# Altium schematic sheet HDMI Interface.SchDoc
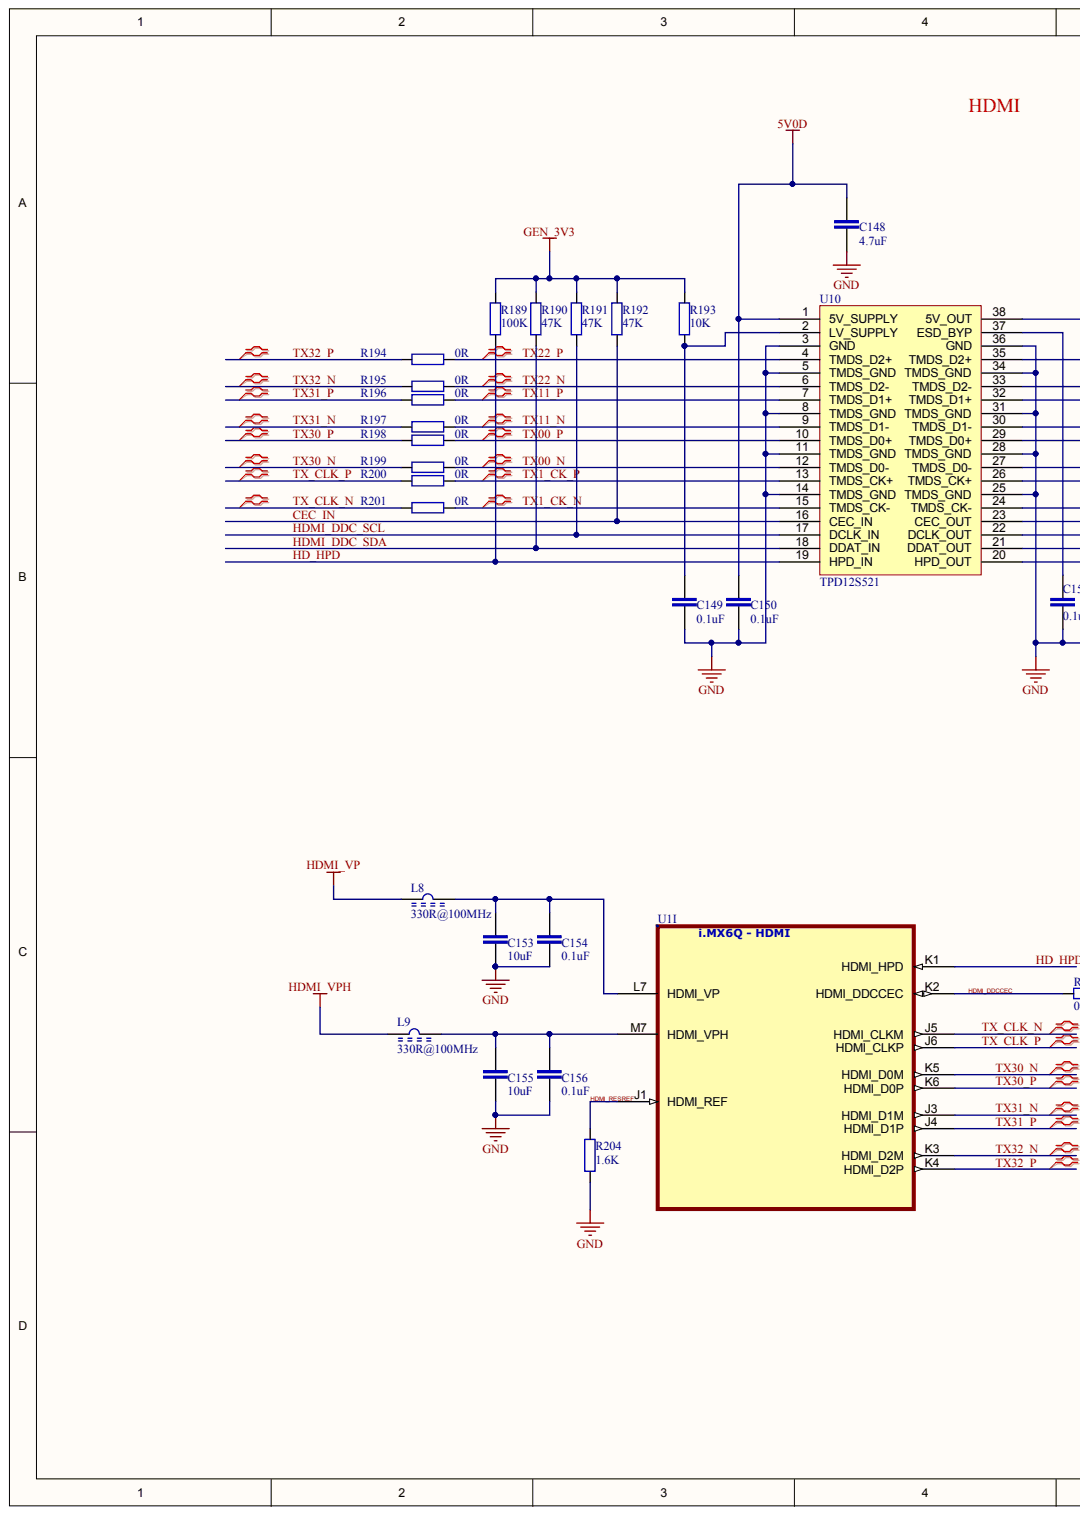
[diagram p0: HDMI Interface.SchDoc, left]
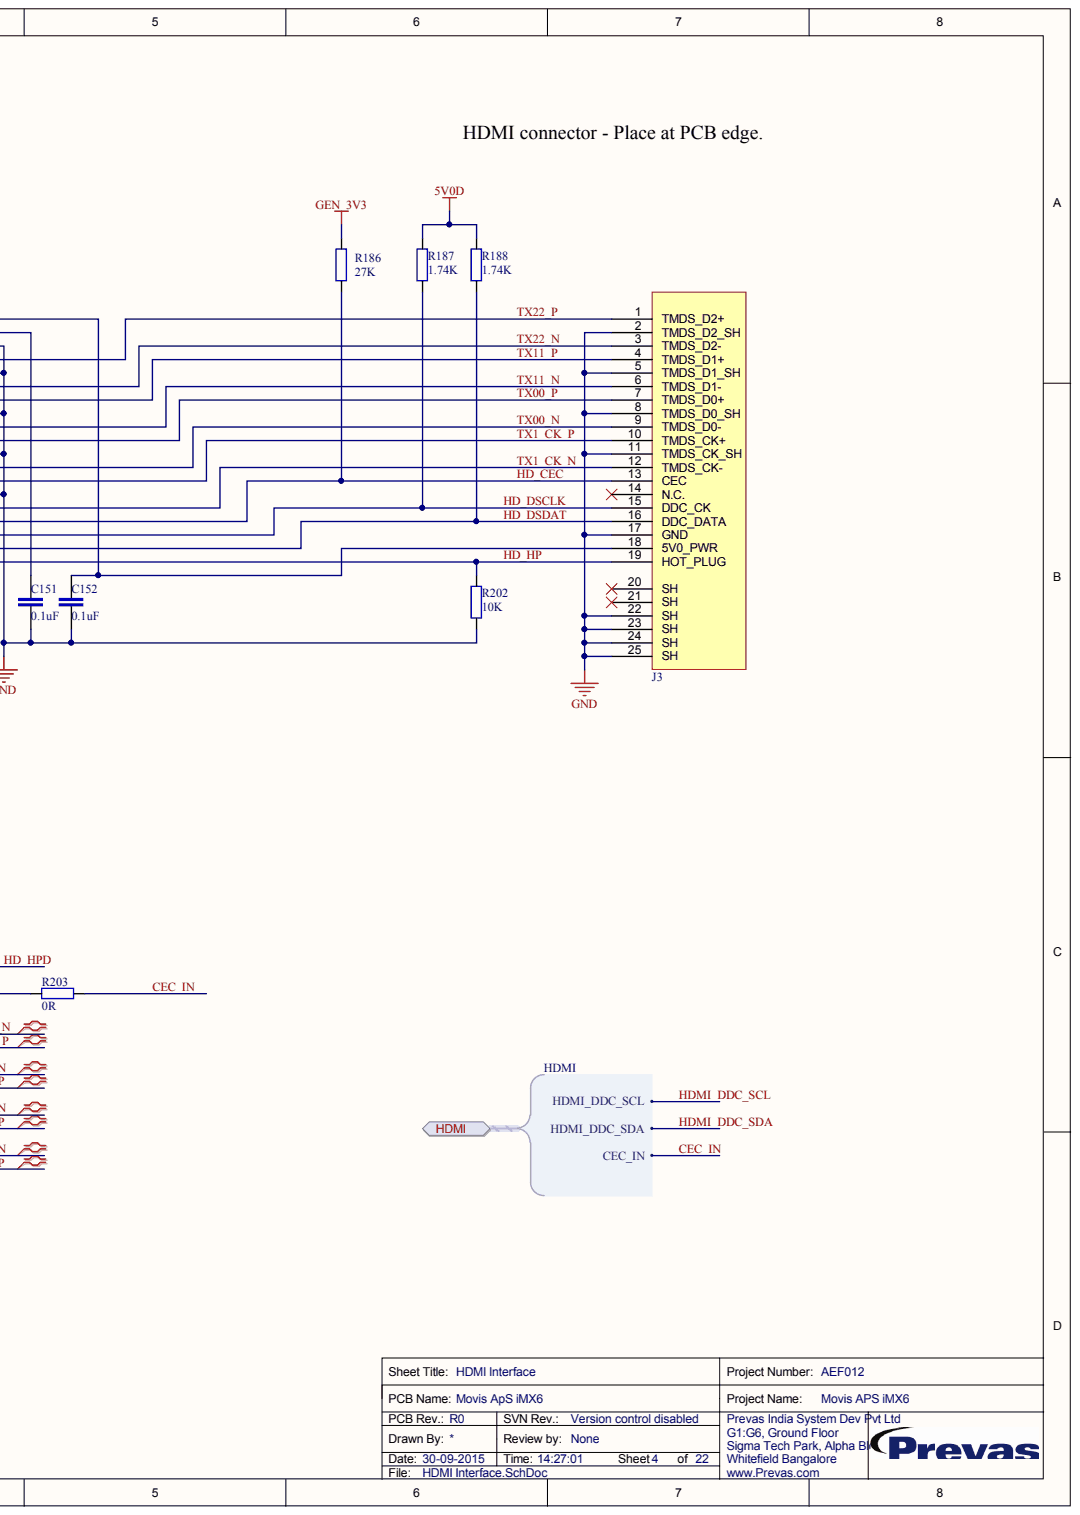
[diagram p1: HDMI Interface.SchDoc, right]

## Parts
C148  4.7uF  [CAPC1608X90N]
C149  0.1uF  [CAPC1005X55N]
C150  0.1uF  [CAPC1005X55N]
C151  0.1uF  [CAPC1005X55N]
C152  0.1uF  [CAPC1005X55N]
C153  10uF  [CAPC1608X95N]
C154  0.1uF  [CAPC1005X55N]
C155  10uF  [CAPC1608X95N]
C156  0.1uF  [CAPC1005X55N]
J3  2007435-1  [CON_HDMI_2007435-1]  (value not drawn)
L8  330R@100MHz  [INDC1608X95N]
L9  330R@100MHz  [INDC1608X95N]
R186  27K  [RESC1608X55N]
R187  1.74K  [RESC1608X55N]
R188  1.74K  [RESC1608X55N]
R189  100K  [RESC1608X55N]
R190  47K  [RESC1608X55N]
R191  47K  [RESC1608X55N]
R192  47K  [RESC1608X55N]
R193  10K  [RESC1608X55N]
R194  0R  [RESC1608X55N]
R195  0R  [RESC1608X55N]
R196  0R  [RESC1608X55N]
R197  0R  [RESC1608X55N]
R198  0R  [RESC1608X55N]
R199  0R  [RESC1608X55N]
R200  0R  [RESC1608X55N]
R201  0R  [RESC1608X55N]
R202  10K  [RESC1608X55N]
R203  0R  [RESC1608X55N]
R204  1.6K  [RESC1608X55N]
U1  MCIMX6Q6AVT10AC  [BGA624C80P25X25_2100X2100X160]  (value not drawn)  (part I)
U10  TPD12S521  [SOP50P640X120-38N]

## Ports
HDMI, HDMI.CEC_IN, HDMI.HDMI_DDC_SCL, HDMI.HDMI_DDC_SDA

## Nets
5V0D: C148.2 C150.2 R187.2 R188.2 U10.1(5V_SUPPLY)
GEN_3V3: R186.2 R189.2 R190.2 R191.2 R192.2 R193.2
GND: C148.1 C149.1 C150.1 C151.1 C152.1 C153.1 C154.1 C155.1 C156.1 J3.2(TMDS_D2_SH) J3.5(TMDS_D1_SH) J3.8(TMDS_D0_SH) J3.11(TMDS_CK_SH) J3.17(GND) J3.22(SH) J3.23(SH) J3.24(SH) J3.25(SH) R202.1 R204.1 U10.3(GND) U10.5(TMDS_GND) U10.8(TMDS_GND) U10.11(TMDS_GND) U10.14(TMDS_GND) U10.25(TMDS_GND) U10.28(TMDS_GND) U10.31(TMDS_GND) U10.34(TMDS_GND) U10.36(GND)
HDMI_VP: L8.1
HDMI_VPH: L9.1
CEC_IN: R192.1 R203.1 U10.16(CEC_IN) ; port HDMI
HD_CEC: J3.13(CEC) R186.1 U10.23(CEC_OUT)
HD_DSCLK: J3.15(DDC_CK) R187.1 U10.22(DCLK_OUT)
HD_DSDAT: J3.16(DDC_DATA) R188.1 U10.21(DDAT_OUT)
HD_HP: J3.19(HOT_PLUG) R202.2 U10.20(HPD_OUT)
HD_HPD: R189.1 U1.K1(HDMI_HPD) U10.19(HPD_IN)
HDMI_DDC_SCL: R191.1 U10.17(DCLK_IN) ; port HDMI
HDMI_DDC_SDA: R190.1 U10.18(DDAT_IN) ; port HDMI
HDMI_DDCCEC: R203.2 U1.K2(HDMI_DDCCEC)
HDMI_RESREF: R204.2 U1.J1(HDMI_REF)
TX00_N: J3.9(TMDS_D0-) R199.2 U10.12(TMDS_D0-) U10.27(TMDS_D0-)
TX00_P: J3.7(TMDS_D0+) R198.2 U10.10(TMDS_D0+) U10.29(TMDS_D0+)
TX1_CK_N: J3.12(TMDS_CK-) R201.2 U10.15(TMDS_CK-) U10.24(TMDS_CK-)
TX1_CK_P: J3.10(TMDS_CK+) R200.2 U10.13(TMDS_CK+) U10.26(TMDS_CK+)
TX11_N: J3.6(TMDS_D1-) R197.2 U10.9(TMDS_D1-) U10.30(TMDS_D1-)
TX11_P: J3.4(TMDS_D1+) R196.2 U10.7(TMDS_D1+) U10.32(TMDS_D1+)
TX22_N: J3.3(TMDS_D2-) R195.2 U10.6(TMDS_D2-) U10.33(TMDS_D2-)
TX22_P: J3.1(TMDS_D2+) R194.2 U10.4(TMDS_D2+) U10.35(TMDS_D2+)
TX30_N: R199.1 U1.K5(HDMI_D0M)
TX30_P: R198.1 U1.K6(HDMI_D0P)
TX31_N: R197.1 U1.J3(HDMI_D1M)
TX31_P: R196.1 U1.J4(HDMI_D1P)
TX32_N: R195.1 U1.K3(HDMI_D2M)
TX32_P: R194.1 U1.K4(HDMI_D2P)
TX_CLK_N: R201.1 U1.J5(HDMI_CLKM)
TX_CLK_P: R200.1 U1.J6(HDMI_CLKP)
NetC149_2: C149.2 R193.1 U10.2(LV_SUPPLY)
NetC151_2: C151.2 U10.37(ESD_BYP)
NetC152_2: C152.2 J3.18(5V0_PWR) U10.38(5V_OUT)
NetC153_2: C153.2 C154.2 L8.2 U1.L7(HDMI_VP)
NetC155_2: C155.2 C156.2 L9.2 U1.M7(HDMI_VPH)

Not connected: J3 14(N.C.) 20(SH) 21(SH)

## Library data of the parts
C148 = INNISI-102: Ceramic capacitor X5R 0603; ref_part_type: /capacitor/non_polarized/ceramic/; source_manufacturer_1: TAIYO YUDEN; source_manufacturer_1_pn: EMK107ABJ475KA-T; cae_altium_pcb_status: Prototype; Database Table Name: altium_default; db_rev: 7663; elec_temp_range: -55 to +85; elec_tolerance: 10%; elec_voltage_rating: 16V; phy_height: 0.90mm; phy_mounting: SMD; phy_packaging_type: 0603; ref_keyword: Multilayer chip capacitor; cae_altium_sch_status: Prototype; Component Kind: Standard; ComponentLink1Description: Datasheet; db_created: 2015-08-04 09:58:51.0; db_last_updated: 04-08-2015 09:58:53; db_local_id: INNISI-102; db_status: Prototype; elec_value: 4.7uF; phy_material: X5R; Library Name: altium_mysql.dblib
C149-C152 C154 C156 = INNISI-73: CAP CER 0.1UF 50V 10% X5R 0402; ref_part_type: /capacitor/non_polarized/ceramic/; source_manufacturer_1: TDK Corporation; source_manufacturer_1_pn: C1005X5R1H104K050BB; cae_altium_pcb_status: Prototype; Database Table Name: altium_default; db_rev: 7569; elec_temp_range: -55 to +85 C; phy_height: 0.55mm; phy_mounting: SMD; phy_packaging_type: 0402; ref_datasheet: INNISI-71; cae_altium_sch_status: Prototype; Component Kind: Standard; ComponentLink1Description: Datasheet; ComponentLink1URL: https://cop201.prevas.dk/viewvc/datasheet/INNISI-71.pdf?root=hw_components&view=co; db_created: 2015-08-03 03:34:59.0; db_last_updated: 03-08-2015 03:35:01; db_local_id: INNISI-73; db_status: Prototype; elec_tolerance: ±10%; elec_value: 0.1uF; elec_voltage_rating: 50V; phy_material: X5R; ref_keyword: CAP CER 0.1UF 50V 10% X5R 0402; Library Name: altium_mysql.dblib
C153 C155 = innisi-78: CAP CER 10UF 16V 10% X5R 0603; ref_part_type: /capacitor/non_polarized/ceramic/; source_manufacturer_1: Murata; source_manufacturer_1_pn: GRM188R61C106KAALD; cae_altium_pcb_status: Prototype; Database Table Name: altium_default; db_rev: 7574; elec_temp_range: -55 to +85C; phy_height: 0.95mm; phy_mounting: SMD; phy_packaging_type: 0603; ref_datasheet: INNISI-75; ref_keyword: Ceramic capacitor X5R 0603; cae_altium_sch_status: Prototype; Component Kind: Standard; ComponentLink1Description: Datasheet; ComponentLink1URL: https://cop201.prevas.dk/viewvc/datasheet/INNISI-75.pdf?root=hw_components&view=co; db_created: 2015-08-03 05:08:42.0; db_last_updated: 03-08-2015 05:08:44; db_local_id: INNISI-78; db_status: Prototype; elec_tolerance: 10%; elec_value: 10uF; elec_voltage_rating: 16V; phy_material: X5R; Library Name: altium_mysql.dblib
J3 = INNISI-64: HDMI, Displayport & DVI Connectors RECPT TYPE A 1P FLAG; ref_part_type: /connector/; source_manufacturer_1: TE Connectivity; cae_altium_pcb_status: Prototype; Database Table Name: altium_default; db_rev: 7514; elec_current_rating: 0.5 A; elec_voltage_rating: 40 V; phy_material: Copper Alloy; phy_mounting: Leaded; ref_datasheet: INNISI-63; ref_keyword: HDMI Connector; cae_altium_sch_status: Prototype; Component Kind: Standard; ComponentLink1Description: Datasheet; ComponentLink1URL: https://cop201.prevas.dk/viewvc/datasheet/INNISI-63.pdf?root=hw_components&view=co; db_created: 2015-06-18 08:45:40.0; db_last_updated: 10-07-2015 09:44:48; db_local_id: INNISI-64; db_status: Prototype; elec_value: 2007435-1; source_manufacturer_1_pn: 2007435-1; Library Name: altium_mysql.dblib
L8 L9 = TELA-164: Chip EMIFIL Inductor Type, 330R@100MHz, 0.15R@0Hz; ref_part_type: /inductor/lossy/; source_manufacturer_1: Murata; source_manufacturer_1_pn: BLM18PG331SN1*; cae_altium_pcb_status: Prototype; Database Table Name: altium_default; db_rev: 5543; elec_current_rating: 1.2A; elec_frequency_rating: 100MHz; elec_temp_range: -55 to 125; elec_tolerance: 25%; phy_height: 0.95mm; phy_material: Ferrite; phy_mounting: SMD; phy_packaging_type: 0603; ref_datasheet: SOMO-49; ref_keyword: Ferrite bead 0603; cae_altium_sch_status: Prototype; Component Kind: Standard; ComponentLink1Description: Datasheet; ComponentLink1URL: https://cop201.prevas.dk/viewvc/datasheet/SOMO-49.pdf?root=hw_components&view=co; db_created: 2013-03-14 15:35:31.0; db_last_updated: 14-03-2013 16:11:58; db_local_id: TELA-164; db_status: Prototype; elec_value: 330R@100MHz; Library Name: altium_mysql.dblib
R186 = INVIHA-18: RES SMD 27K OHM 1% 1/10W 0603; ref_part_type: /resistor/fixed/; source_manufacturer_1: Yageo; source_manufacturer_1_pn: RC0603FR-0727KL; cae_altium_pcb_status: Prototype; Database Table Name: altium_default; db_rev: 7600; elec_power_rating: 0.1W; elec_temp_range: -55 to +155° C; phy_height: 0.55mm; phy_packaging_type: 0603; ref_datasheet: INVIHA-2; ref_keyword: Chip Resistor; cae_altium_sch_status: Prototype; Component Kind: Standard; ComponentLink1Description: Datasheet; ComponentLink1URL: https://cop201.prevas.dk/viewvc/datasheet/INVIHA-2.pdf?root=hw_components&view=co; db_created: 2015-08-03 07:06:44.0; db_last_updated: 03-08-2015 7:06:46 AM; db_local_id: INVIHA-18; db_status: Prototype; elec_tolerance: 1%; elec_value: 27K; phy_mounting: SMD; Library Name: altium_mysql.dblib
R187 R188 = INVIHA-19: RES SMD 1.74K OHM 1% 1/10W 0603; ref_part_type: /resistor/fixed/; source_manufacturer_1: Yageo; source_manufacturer_1_pn: RC0603FR-071K74L; cae_altium_pcb_status: Prototype; Database Table Name: altium_default; db_rev: 7601; elec_power_rating: 0.1W; elec_temp_range: -55 to +155° C; phy_height: 0.55mm; phy_packaging_type: 0603; ref_datasheet: INVIHA-2; ref_keyword: Chip Resistor; cae_altium_sch_status: Prototype; Component Kind: Standard; ComponentLink1Description: Datasheet; ComponentLink1URL: https://cop201.prevas.dk/viewvc/datasheet/INVIHA-2.pdf?root=hw_components&view=co; db_created: 2015-08-03 07:11:02.0; db_last_updated: 03-08-2015 7:11:04 AM; db_local_id: INVIHA-19; db_status: Prototype; elec_tolerance: 1%; elec_value: 1.74K; phy_mounting: SMD; Library Name: altium_mysql.dblib
R189 = INVIHA-20: RES SMD 100K OHM 1% 1/10W 0603; ref_part_type: /resistor/fixed/; source_manufacturer_1: Yageo; source_manufacturer_1_pn: RC0603FR-07100KL; cae_altium_pcb_status: Prototype; Database Table Name: altium_default; db_rev: 7602; elec_power_rating: 0.1W; elec_temp_range: -55 to +155° C; phy_height: 0.55mm; phy_packaging_type: 0603; ref_keyword: Chip Resistor; cae_altium_sch_status: Prototype; Component Kind: Standard; ComponentLink1Description: Datasheet; ComponentLink1URL: https://cop201.prevas.dk/viewvc/datasheet/INVIHA-2.pdf?root=hw_components&view=co; db_created: 2015-08-03 07:16:43.0; db_last_updated: 03-08-2015 7:16:45 AM; db_local_id: INVIHA-20; db_status: Prototype; elec_tolerance: 1%; elec_value: 100K; phy_mounting: SMD; ref_datasheet: INVIHA-2; Library Name: altium_mysql.dblib
R190-R192 = INVIHA-21: RES SMD 47K OHM 1% 1/10W 0603; ref_part_type: /resistor/fixed/; source_manufacturer_1: Yageo; source_manufacturer_1_pn: RC0603FR-0747KL; cae_altium_pcb_status: Prototype; Database Table Name: altium_default; db_rev: 7604; elec_power_rating: 0.1W; elec_temp_range: -55 to +155° C; phy_height: 0.55mm; phy_packaging_type: 0603; ref_keyword: Chip Resistor; cae_altium_sch_status: Prototype; Component Kind: Standard; ComponentLink1Description: Datasheet; ComponentLink1URL: https://cop201.prevas.dk/viewvc/datasheet/INVIHA-2.pdf?root=hw_components&view=co; db_created: 2015-08-03 07:24:13.0; db_last_updated: 03-08-2015 7:24:15 AM; db_local_id: INVIHA-21; db_status: Prototype; elec_tolerance: 1%; elec_value: 47K; phy_mounting: SMD; ref_datasheet: INVIHA-2; Library Name: altium_mysql.dblib
R193 R202 = INVIHA-3: RES SMD 10K OHM 1% 1/10W 0603; ref_part_type: /resistor/fixed/; source_manufacturer_1: Yageo; source_manufacturer_1_pn: RC0603FR-0710KL; cae_altium_pcb_status: Prototype; Database Table Name: altium_default; db_rev: 7575; elec_power_rating: 0.1W; elec_temp_range: -55 to +155° C; phy_height: 0.55mm; phy_packaging_type: 0603; ref_datasheet: INVIHA-2; ref_keyword: Chip Resistor; cae_altium_sch_status: Prototype; Component Kind: Standard; ComponentLink1Description: Datasheet; ComponentLink1URL: https://cop201.prevas.dk/viewvc/datasheet/INVIHA-2.pdf?root=hw_components&view=co; db_created: 2015-08-03 05:39:03.0; db_last_updated: 03-08-2015 5:39:05 AM; db_local_id: INVIHA-3; db_status: Prototype; elec_tolerance: 1%; elec_value: 10K; phy_mounting: SMD; Library Name: altium_mysql.dblib
R194-R201 R203 = INVIHA-4: RES SMD 0.0 OHM JUMPER 1/10W; ref_part_type: /resistor/fixed/; source_manufacturer_1: Panasonic Electronic Components; source_manufacturer_1_pn: ERJ-3GEY0R00V; cae_altium_pcb_status: Prototype; Database Table Name: altium_default; db_rev: 7580; elec_power_rating: 0.1W; elec_temp_range: -55 to +155° C; phy_height: 0.55mm; phy_packaging_type: 0603; ref_datasheet: INVIHA-3; ref_keyword: Chip Resistor; cae_altium_sch_status: Prototype; Component Kind: Standard; ComponentLink1Description: Datasheet; ComponentLink1URL: https://cop201.prevas.dk/viewvc/datasheet/INVIHA-3.pdf?root=hw_components&view=co; db_created: 2015-08-03 05:59:19.0; db_last_updated: 03-08-2015 5:59:21 AM; db_local_id: INVIHA-4; db_status: Prototype; elec_value: 0R; phy_mounting: SMD; Library Name: altium_mysql.dblib
R204 = INVIHA-22: RES SMD 1.6K OHM 1% 1/10W 0603; ref_part_type: /resistor/fixed/; source_manufacturer_1: Yageo; source_manufacturer_1_pn: RC0603FR-071K6L; cae_altium_pcb_status: Prototype; Database Table Name: altium_default; db_rev: 7605; elec_power_rating: 0.1W; elec_temp_range: -55 to +155° C; phy_height: 0.55mm; phy_packaging_type: 0603; ref_keyword: Chip Resistor; cae_altium_sch_status: Prototype; Component Kind: Standard; ComponentLink1Description: Datasheet; ComponentLink1URL: https://cop201.prevas.dk/viewvc/datasheet/INVIHA-2.pdf?root=hw_components&view=co; db_created: 2015-08-03 07:25:35.0; db_last_updated: 03-08-2015 7:25:37 AM; db_local_id: INVIHA-22; db_status: Prototype; elec_tolerance: 1%; elec_value: 1.6K; phy_mounting: SMD; ref_datasheet: INVIHA-2; Library Name: altium_mysql.dblib
U1 = INRAAN-5: i.MX 6Dual/6Quad; ref_part_type: /integrated/digital/processor/general/; AGILE_PN: 344-01218; CAD_APPROVED: Yes; cae_altium_pcb_status: Prototype; CLASS: IC; Database Table Name: altium_default; db_rev: 6247; elec_temp_range: -40 to +105; elec_voltage_rating: 1.5V; MFG_NAME01: FREESCALE SEMICONDUCTOR; phy_height: 1.85; phy_mounting: SMD; phy_packaging_type: FCPBGA96_624; ref_datasheet: INRAAN-6; cae_altium_sch_status: Prototype; Component Kind: Standard; ComponentLink1Description: Datasheet; ComponentLink1URL: https://cop201.prevas.dk/viewvc/datasheet/INRAAN-6.pdf?root=hw_components&view=co; db_created: 2013-10-21 12:25:57.0; db_last_updated: 27-12-2013 09:32:57; db_local_id: INRAAN-5; db_status: Prototype; elec_value: MCIMX6Q6AVT10AC; HEIGHT: 2.16MM; MFG_PN01: MCIMX6Q6AVT10AC; ref_keyword: i.MX 6Dual/6Quad; ROHS: Yes; source_manufacturer_1: Freescale Semiconductor; source_manufacturer_1_pn: MCIMX6Q6AVT10AC; Library Name: altium_mysql.dblib
U10 = INRAAN-239: ESD Suppressors HDMI RECEIVER PORT PROTECTION; ref_part_type: /filter/esd/; source_manufacturer_1: Texas Instruments; cae_altium_pcb_status: Prototype; Database Table Name: altium_default; db_rev: 6944; elec_temp_range: - 40 C to + 85 C; phy_height: 1.2mm; phy_mounting: SMD; phy_packaging_type: TSSOP-38; ref_datasheet: INRAAN-224; ref_keyword: ESD Protection Device; cae_altium_sch_status: Prototype; Component Kind: Standard; ComponentLink1Description: Datasheet; ComponentLink1URL: https://cop201.prevas.dk/viewvc/datasheet/INRAAN-224.pdf?root=hw_components&view=co; db_created: 2014-12-02 09:10:48.0; db_last_updated: 02-12-2014 09:10:49; db_local_id: INRAAN-239; db_status: Prototype; elec_value: TPD12S521; source_manufacturer_1_pn: TPD12S521; Library Name: altium_mysql.dblib
